# Altium schematic sheet [3]-CPLD.SchDoc
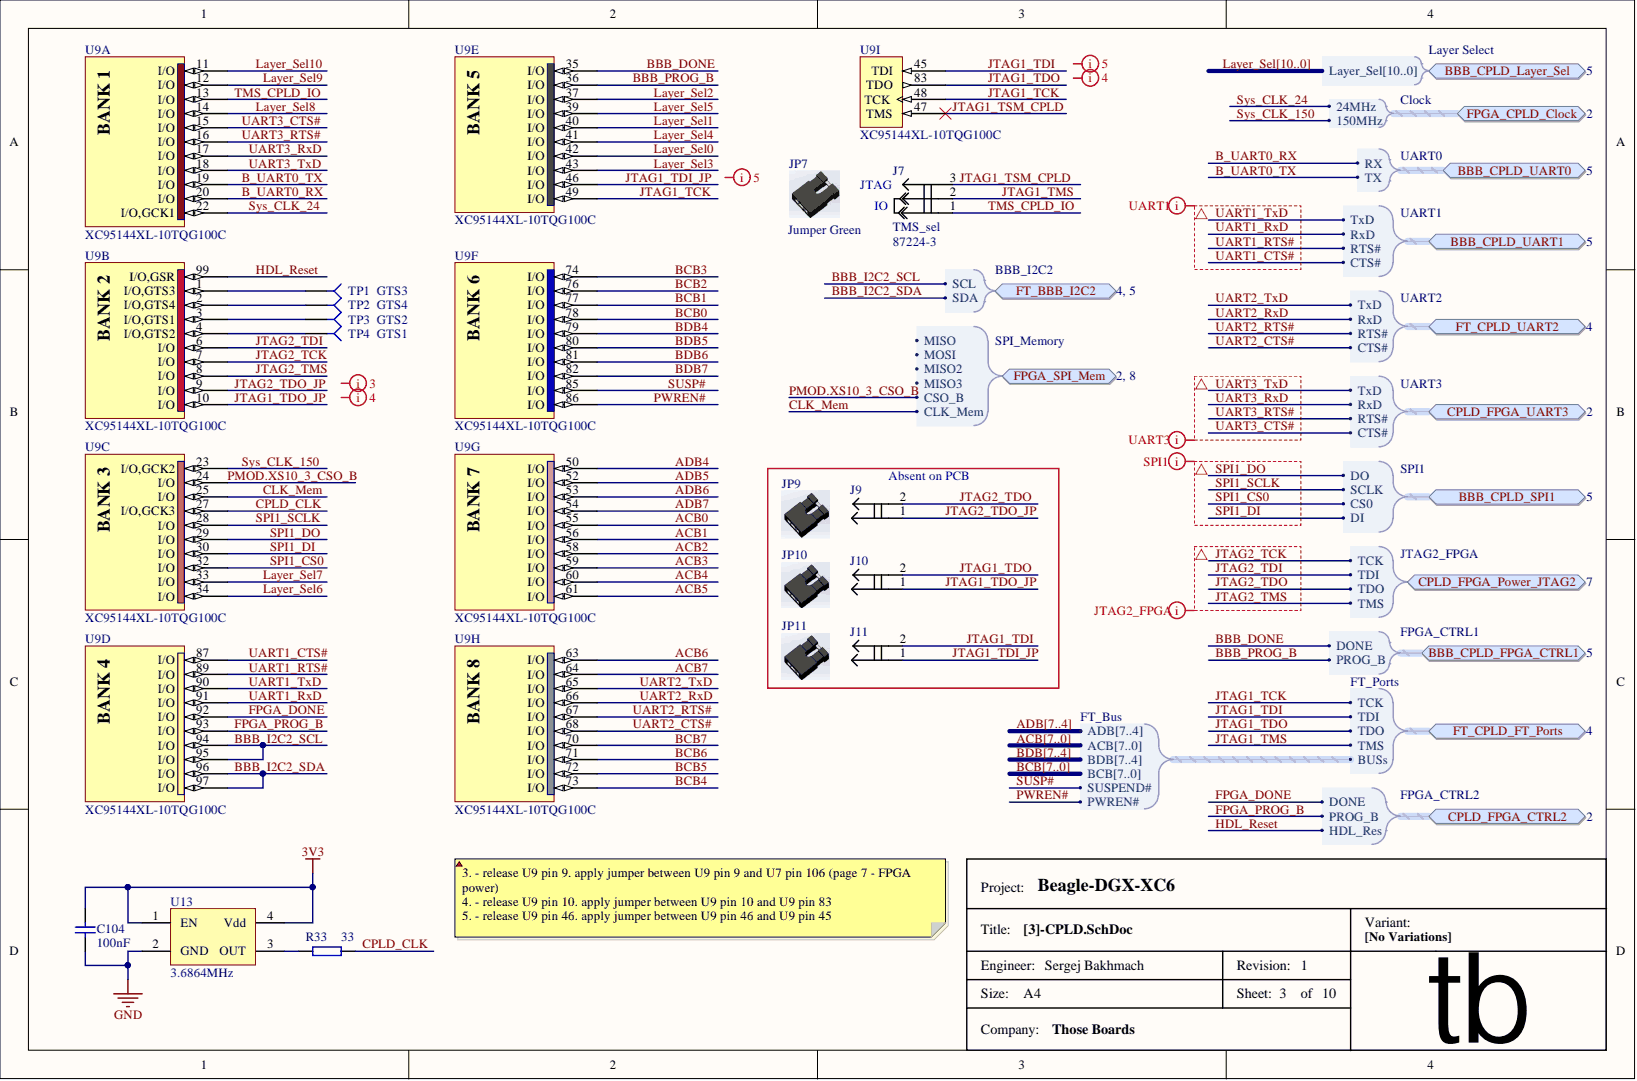
[diagram p0: [3]-CPLD.SchDoc]

## Parts
C104  value 100nF  [C0603]  (hidden comment: CAP)
J7  TMS_sel  value 87224-3  Manufacturer Part: 87224-3  [Jumper_3pin_green]
J9  A0  [Jumper_2pin black_open]  (value not drawn)  (mechanical)
J10  A0  [Jumper_2pin black_open]  (value not drawn)  (mechanical)
J11  A0  [Jumper_2pin black_open]  (value not drawn)  (mechanical)
JP7  value Jumper Green  Supplier Part: Jumper  (hidden comment: VCC_sel)  (mechanical)
JP9  (no value)  (mechanical)
JP10  (no value)  (mechanical)
JP11  (no value)  (mechanical)
R33  value 33  [0603_RESISTOR]  (hidden comment: Resistor)
TP1  GTS3  [TP_R_1.5mm]  (no BOM)
TP2  GTS4  [TP_R_1.5mm]  (no BOM)
TP3  GTS2  [TP_R_1.5mm]  (no BOM)
TP4  GTS1  [TP_R_1.5mm]  (no BOM)
U9  XC95144XL-10TQG100C  [TQ100 - XC95144XL]  (parts A,B,C,D,E,F,G,H,I)
U13  value 3.6864MHz  Manufacturer Part: 3.6864MHz  [OSC_5x7]  (hidden comment: OSC_Crystal Oscillator)

## Ports
BBB_CPLD_FPGA_CTRL1, BBB_CPLD_FPGA_CTRL1.DONE, BBB_CPLD_FPGA_CTRL1.PROG_B, BBB_CPLD_Layer_Sel, BBB_CPLD_LAYER_SEL.LAYER_SEL0, BBB_CPLD_LAYER_SEL.LAYER_SEL1, BBB_CPLD_LAYER_SEL.LAYER_SEL2, BBB_CPLD_LAYER_SEL.LAYER_SEL3, BBB_CPLD_LAYER_SEL.LAYER_SEL4, BBB_CPLD_LAYER_SEL.LAYER_SEL5, BBB_CPLD_LAYER_SEL.LAYER_SEL6, BBB_CPLD_LAYER_SEL.LAYER_SEL7, BBB_CPLD_LAYER_SEL.LAYER_SEL8, BBB_CPLD_LAYER_SEL.LAYER_SEL9, BBB_CPLD_LAYER_SEL.LAYER_SEL10, BBB_CPLD_Layer_Sel.Layer_Sel[10..0], BBB_CPLD_SPI1, BBB_CPLD_SPI1.CS0, BBB_CPLD_SPI1.DI, BBB_CPLD_SPI1.DO, BBB_CPLD_SPI1.SCLK, BBB_CPLD_UART0, BBB_CPLD_UART0.RX, BBB_CPLD_UART0.TX, BBB_CPLD_UART1, BBB_CPLD_UART1.CTS#, BBB_CPLD_UART1.RTS#, BBB_CPLD_UART1.RxD, BBB_CPLD_UART1.TxD, CPLD_FPGA_CTRL2, CPLD_FPGA_CTRL2.DONE, CPLD_FPGA_CTRL2.HDL_Res, CPLD_FPGA_CTRL2.PROG_B, CPLD_FPGA_Power_JTAG2, CPLD_FPGA_Power_JTAG2.TCK, CPLD_FPGA_Power_JTAG2.TDI, CPLD_FPGA_Power_JTAG2.TDO, CPLD_FPGA_Power_JTAG2.TMS, CPLD_FPGA_UART3, CPLD_FPGA_UART3.CTS#, CPLD_FPGA_UART3.RTS#, CPLD_FPGA_UART3.RxD, CPLD_FPGA_UART3.TxD, FPGA_CPLD_Clock, FPGA_CPLD_Clock.24MHz, FPGA_CPLD_Clock.150MHz, FPGA_SPI_Mem, FPGA_SPI_Mem.CLK_Mem, FPGA_SPI_Mem.CSO_B, FPGA_SPI_Mem.MISO, FPGA_SPI_Mem.MISO2, FPGA_SPI_Mem.MISO3, FPGA_SPI_Mem.MOSI, FT_BBB_I2C2, FT_BBB_I2C2.SCL, FT_BBB_I2C2.SDA, FT_CPLD_FT_Ports, FT_CPLD_FT_PORTS.BUSS.ACB0, FT_CPLD_FT_PORTS.BUSS.ACB1, FT_CPLD_FT_PORTS.BUSS.ACB2, FT_CPLD_FT_PORTS.BUSS.ACB3, FT_CPLD_FT_PORTS.BUSS.ACB4, FT_CPLD_FT_PORTS.BUSS.ACB5, FT_CPLD_FT_PORTS.BUSS.ACB6, FT_CPLD_FT_PORTS.BUSS.ACB7, FT_CPLD_FT_Ports.BUSs.ACB[7..0], FT_CPLD_FT_PORTS.BUSS.ADB4, FT_CPLD_FT_PORTS.BUSS.ADB5, FT_CPLD_FT_PORTS.BUSS.ADB6, FT_CPLD_FT_PORTS.BUSS.ADB7, FT_CPLD_FT_Ports.BUSs.ADB[7..4], FT_CPLD_FT_PORTS.BUSS.BCB0, FT_CPLD_FT_PORTS.BUSS.BCB1, FT_CPLD_FT_PORTS.BUSS.BCB2, FT_CPLD_FT_PORTS.BUSS.BCB3, FT_CPLD_FT_PORTS.BUSS.BCB4, FT_CPLD_FT_PORTS.BUSS.BCB5, FT_CPLD_FT_PORTS.BUSS.BCB6, FT_CPLD_FT_PORTS.BUSS.BCB7, FT_CPLD_FT_Ports.BUSs.BCB[7..0], FT_CPLD_FT_PORTS.BUSS.BDB4, FT_CPLD_FT_PORTS.BUSS.BDB5, FT_CPLD_FT_PORTS.BUSS.BDB6, FT_CPLD_FT_PORTS.BUSS.BDB7, FT_CPLD_FT_Ports.BUSs.BDB[7..4], FT_CPLD_FT_Ports.BUSs.PWREN#, FT_CPLD_FT_Ports.BUSs.SUSPEND#, FT_CPLD_FT_Ports.TCK, FT_CPLD_FT_Ports.TDI, FT_CPLD_FT_Ports.TDO, FT_CPLD_FT_Ports.TMS, FT_CPLD_UART2, FT_CPLD_UART2.CTS#, FT_CPLD_UART2.RTS#, FT_CPLD_UART2.RxD, FT_CPLD_UART2.TxD

## Nets
3V3: C104.2 U13.1(EN) U13.4(Vdd)
GND: C104.1 U13.2(GND)
ACB0: U9.55(I/O) ; port FT_CPLD_FT_Ports  [also labelled ACB]
ACB1: U9.56(I/O) ; port FT_CPLD_FT_Ports  [also labelled ACB]
ACB2: U9.58(I/O) ; port FT_CPLD_FT_Ports  [also labelled ACB]
ACB3: U9.59(I/O) ; port FT_CPLD_FT_Ports  [also labelled ACB]
ACB4: U9.60(I/O) ; port FT_CPLD_FT_Ports  [also labelled ACB]
ACB5: U9.61(I/O) ; port FT_CPLD_FT_Ports  [also labelled ACB]
ACB6: U9.63(I/O) ; port FT_CPLD_FT_Ports  [also labelled ACB]
ACB7: U9.64(I/O) ; port FT_CPLD_FT_Ports  [also labelled ACB]
ADB4: U9.50(I/O) ; port FT_CPLD_FT_Ports  [also labelled ADB]
ADB5: U9.52(I/O) ; port FT_CPLD_FT_Ports  [also labelled ADB]
ADB6: U9.53(I/O) ; port FT_CPLD_FT_Ports  [also labelled ADB]
ADB7: U9.54(I/O) ; port FT_CPLD_FT_Ports  [also labelled ADB]
B_UART0_RX: U9.20(I/O) ; port BBB_CPLD_UART0
B_UART0_TX: U9.19(I/O) ; port BBB_CPLD_UART0
BBB_DONE: U9.35(I/O) ; port BBB_CPLD_FPGA_CTRL1
BBB_I2C2_SCL: U9.94(I/O) U9.95(I/O) ; port FT_BBB_I2C2
BBB_I2C2_SDA: U9.96(I/O) U9.97(I/O) ; port FT_BBB_I2C2
BBB_PROG_B: U9.36(I/O) ; port BBB_CPLD_FPGA_CTRL1
BCB0: U9.78(I/O) ; port FT_CPLD_FT_Ports  [also labelled BCB]
BCB1: U9.77(I/O) ; port FT_CPLD_FT_Ports  [also labelled BCB]
BCB2: U9.76(I/O) ; port FT_CPLD_FT_Ports  [also labelled BCB]
BCB3: U9.74(I/O) ; port FT_CPLD_FT_Ports  [also labelled BCB]
BCB4: U9.73(I/O) ; port FT_CPLD_FT_Ports  [also labelled BCB]
BCB5: U9.72(I/O) ; port FT_CPLD_FT_Ports  [also labelled BCB]
BCB6: U9.71(I/O) ; port FT_CPLD_FT_Ports  [also labelled BCB]
BCB7: U9.70(I/O) ; port FT_CPLD_FT_Ports  [also labelled BCB]
BDB4: U9.79(I/O) ; port FT_CPLD_FT_Ports  [also labelled BDB]
BDB5: U9.80(I/O) ; port FT_CPLD_FT_Ports  [also labelled BDB]
BDB6: U9.81(I/O) ; port FT_CPLD_FT_Ports  [also labelled BDB]
BDB7: U9.82(I/O) ; port FT_CPLD_FT_Ports  [also labelled BDB]
CLK_Mem: U9.25(I/O) ; port FPGA_SPI_Mem
CPLD_CLK: R33.2 U9.27(I/O,GCK3)
FPGA_DONE: U9.92(I/O) ; port CPLD_FPGA_CTRL2
FPGA_PROG_B: U9.93(I/O) ; port CPLD_FPGA_CTRL2
HDL_Reset: U9.99(I/O,GSR) ; port CPLD_FPGA_CTRL2
JTAG1_TCK: U9.48(TCK) U9.49(I/O) ; port FT_CPLD_FT_Ports
JTAG1_TDI: J11.2 U9.45(TDI) ; port FT_CPLD_FT_Ports
JTAG1_TDI_JP: J11.1 U9.46(I/O)
JTAG1_TDO: J10.2 U9.83(TDO) ; port FT_CPLD_FT_Ports
JTAG1_TDO_JP: J10.1 U9.10(I/O)
JTAG1_TMS: J7.2 ; port FT_CPLD_FT_Ports
JTAG1_TSM_CPLD: J7.3 U9.47(TMS)
JTAG2_TCK: U9.7(I/O) ; port CPLD_FPGA_Power_JTAG2
JTAG2_TDI: U9.6(I/O) ; port CPLD_FPGA_Power_JTAG2
JTAG2_TDO: J9.2 ; port CPLD_FPGA_Power_JTAG2
JTAG2_TDO_JP: J9.1 U9.9(I/O)
JTAG2_TMS: U9.8(I/O) ; port CPLD_FPGA_Power_JTAG2
Layer_Sel0: U9.42(I/O) ; port BBB_CPLD_Layer_Sel  [also labelled LAYER_SEL]
Layer_Sel1: U9.40(I/O) ; port BBB_CPLD_Layer_Sel  [also labelled LAYER_SEL]
Layer_Sel2: U9.37(I/O) ; port BBB_CPLD_Layer_Sel  [also labelled LAYER_SEL]
Layer_Sel3: U9.43(I/O) ; port BBB_CPLD_Layer_Sel  [also labelled LAYER_SEL]
Layer_Sel4: U9.41(I/O) ; port BBB_CPLD_Layer_Sel  [also labelled LAYER_SEL]
Layer_Sel5: U9.39(I/O) ; port BBB_CPLD_Layer_Sel  [also labelled LAYER_SEL]
Layer_Sel6: U9.34(I/O) ; port BBB_CPLD_Layer_Sel  [also labelled LAYER_SEL]
Layer_Sel7: U9.33(I/O) ; port BBB_CPLD_Layer_Sel  [also labelled LAYER_SEL]
Layer_Sel8: U9.14(I/O) ; port BBB_CPLD_Layer_Sel  [also labelled LAYER_SEL]
Layer_Sel9: U9.12(I/O) ; port BBB_CPLD_Layer_Sel  [also labelled LAYER_SEL]
Layer_Sel10: U9.11(I/O) ; port BBB_CPLD_Layer_Sel  [also labelled LAYER_SEL]
PMOD.XS10_3_CSO_B: U9.24(I/O) ; port FPGA_SPI_Mem
PWREN#: U9.86(I/O) ; port FT_CPLD_FT_Ports
SPI1_CS0: U9.32(I/O) ; port BBB_CPLD_SPI1
SPI1_DI: U9.30(I/O) ; port BBB_CPLD_SPI1
SPI1_DO: U9.29(I/O) ; port BBB_CPLD_SPI1
SPI1_SCLK: U9.28(I/O) ; port BBB_CPLD_SPI1
SUSP#: U9.85(I/O) ; port FT_CPLD_FT_Ports
Sys_CLK_24: U9.22(I/O,GCK1) ; port FPGA_CPLD_Clock
Sys_CLK_150: U9.23(I/O,GCK2) ; port FPGA_CPLD_Clock
TMS_CPLD_IO: J7.1 U9.13(I/O)
UART1_CTS#: U9.87(I/O) ; port BBB_CPLD_UART1
UART1_RTS#: U9.89(I/O) ; port BBB_CPLD_UART1
UART1_RxD: U9.91(I/O) ; port BBB_CPLD_UART1
UART1_TxD: U9.90(I/O) ; port BBB_CPLD_UART1
UART2_CTS#: U9.68(I/O) ; port FT_CPLD_UART2
UART2_RTS#: U9.67(I/O) ; port FT_CPLD_UART2
UART2_RxD: U9.66(I/O) ; port FT_CPLD_UART2
UART2_TxD: U9.65(I/O) ; port FT_CPLD_UART2
UART3_CTS#: U9.15(I/O) ; port CPLD_FPGA_UART3
UART3_RTS#: U9.16(I/O) ; port CPLD_FPGA_UART3
UART3_RxD: U9.17(I/O) ; port CPLD_FPGA_UART3
UART3_TxD: U9.18(I/O) ; port CPLD_FPGA_UART3
NetR33_1: R33.1 U13.3(OUT)
NetTP1_1: TP1.1 U9.1(I/O,GTS3)
NetTP2_1: TP2.1 U9.2(I/O,GTS4)
NetTP3_1: TP3.1 U9.3(I/O,GTS1)
NetTP4_1: TP4.1 U9.4(I/O,GTS2)
N00144:
N00145:
N00146:
N00147:
N00148:
N00149:
N00150: ; port FPGA_SPI_Mem
N00151: ; port FPGA_SPI_Mem
N00152: ; port FPGA_SPI_Mem
N00153: ; port FPGA_SPI_Mem
N00154:
N00155:
N00156:

## Library data of the parts
C104 = CAP: Manufacturer: Vishay / Vitramon; Manufacturer Part: VJ0603Y104JXJPW1BC; Type of Component: Ceramic Capacitor; Component Kind: Standard; Manufacturer Link: http://www.vishay.com/product?docid=28548; Package / Case: 0603; Supplier: mouser; Supplier Link: http://www.mouser.com/ProductDetail/Vishay/VJ0603Y104JXJPW1BC/?qs=%2fha2pyFaduidGoO0X7aK7Xgs%2fjxfhnLiK6SRw4Lz0%252bZK3PJ8DddTrQ%3d%3d; Supplier Part: 77-VJ0603Y104JXJPBC; Library Name: RPI-VITA.IntLib
J7 = jumper_3pin: Manufacturer: TE Connectivity / AMP; Type of Component: Jumper; Component Kind: Standard; Manufacturer Link: http://www.te.com/usa-en/product-87224-3.html#pdp-related-products-inner; Package / Case: Header 1 x 3; Supplier: mouser; Supplier Link: http://www.mouser.ee/ProductDetail/TE-Connectivity-AMP/87224-3/?qs=sGAEpiMZZMs%252bGHln7q6pm48SVpWlpfsErguO%2fp0873w%3d; Supplier Part: 571-872243; Library Name: RPI-VITA.IntLib
J9-J11 = jumper_2pin_open: Jumper 2pin Open; Type of Component: Header for jumper 2 pin; Value: Header 1x2; Package / Case: 2.54mm; Supplier 1: aliexpress.com; Supplier 1 Link: aliexpress.com/item/1Set-20PCS-40Pin-2-54mm-Single-Row-Straight-Male-Pin-Header-Connector-Strip-For-PBC-Ardunio/32329088739.html?spm=2114.03010208.3.2.0ijeiM&ws_ab_test=searchweb201556_1,searchweb201644_1_505_506_503_504_502_10001_10002_10017_10010_10005_10011_10006_10003_10004_10009_10007_10008,searchweb201560_5,searchweb1451318400_-1,searchweb1451318411_6452&btsid=d8fe2734-cbe4-429c-a307-e5400297e31b; Supplier 2: http://imrad.com.ua; Supplier 2 Link: http://imrad.com.ua/ru/pls-40-product-122266; Supplier 2 Part: 202826; Supplier 3: http://voron.ua; Supplier 3 Link: http://voron.ua/catalog/000631; Supplier 3 Part: 000631; Library Name: RPI-VITA.IntLib
JP7 = jumper green: Manufacturer: Great Electric; Manufacturer Part: Jumper Cap Shunts Short Circuit Cap; Package / Case: 2.54mm; Supplier: aliexpress.com; Supplier Link: http://www.aliexpress.com/item/500pcs-lot-Asssorted-Color-2-54mm-Standard-Circuit-Board-Jumper-Cap-Shunts-Short-Circuit-Cap-CGKCH119/32344305619.html?spm=2114.03010208.3.80.nPPmoy&ws_ab_test=searchweb201556_1,searchweb201644_1_505_506_503_504_502_10001_10002_10017_10010_10005_10011_10006_10003_10004_10009_10007_10008,searchweb201560_5,searchweb1451318400_-1,searchweb1451318411_6452&btsid=16d11777-edde-4755-b8b5-9adacb495b09; Type of Component: Jumper; Library Name: RPI-VITA.IntLib
JP9-JP11 = jumper black: Library Name: RPI-VITA.IntLib
R33 = Resistor: Manufacturer: Vishay / Dale; Manufacturer Part: CRCW060333R0FKEA; Component Kind: Standard; Manufacturer Link: http://www.vishay.com/product?docid=20035; Package / Case: 0603; Supplier: mouser; Supplier Link: http://www2.mouser.com/ProductDetail/Vishay-Dale/CRCW060333R0FKEA/?qs=sGAEpiMZZMvdGkrng054t1X0qgDPqELUwAjRIFbU4%252bo%3d; Supplier Part: 71-CRCW0603-33-E3; Type of Component: Resistor; Library Name: RPI-VITA.IntLib
TP1-TP4 = TP: Test Point; Library Name: RPI-VITA.IntLib
U9 = XC95144XL-10TQG100C: The XC95144XL is a 3.3V CPLD targeted for high-performance,  low-voltage applications in leading-edge communications  and computing systems.; Manufacturer: Xilinx Inc; Component Kind: Standard; Manufacturer Link: http://xgoogle.xilinx.com/search?output=xml_no_dtd&ie=UTF-8&oe=UTF-8&client=xilinx&proxystylesheet=xilinx&site=EntireSite&filter=0&num=200&sort=date:D:L:d1&getfields=*&show_dynamic_navigation=1&requiredfields=-Archived%3Atrue&q=XC95144XL-10TQG100C&as_q=; Manufacturer Part: XC95144XL-10TQG100C; Package / Case: TQG-100; Supplier 1: HK-Ada; Supplier 1 Part: XC95144XL-10TQG100C; Type of Component: CPLD; Value: XC95144XL-10TQG100C; Library Name: RPI-VITA.IntLib
U13 = OSC_Crystal Oscillator: Manufacturer: KKQ; Type of Component: Clock Oscillator; Component Kind: Standard; Package / Case: 5 mm x 7 mm; Supplier: aliexpress.com; Supplier Link: http://aliexpress.com/item/100PCS-Original-NEW-3-6864MHZ-3-6864M-3-6864-5-7-4P-5070-OSC-5-00mm/32275012196.html?detailNewVersion=1; Supplier Part: 3.6864MHz 4 pin; Library Name: RPI-VITA.IntLib
